# Altium schematic sheet A4-4G_MODULE.SchDoc
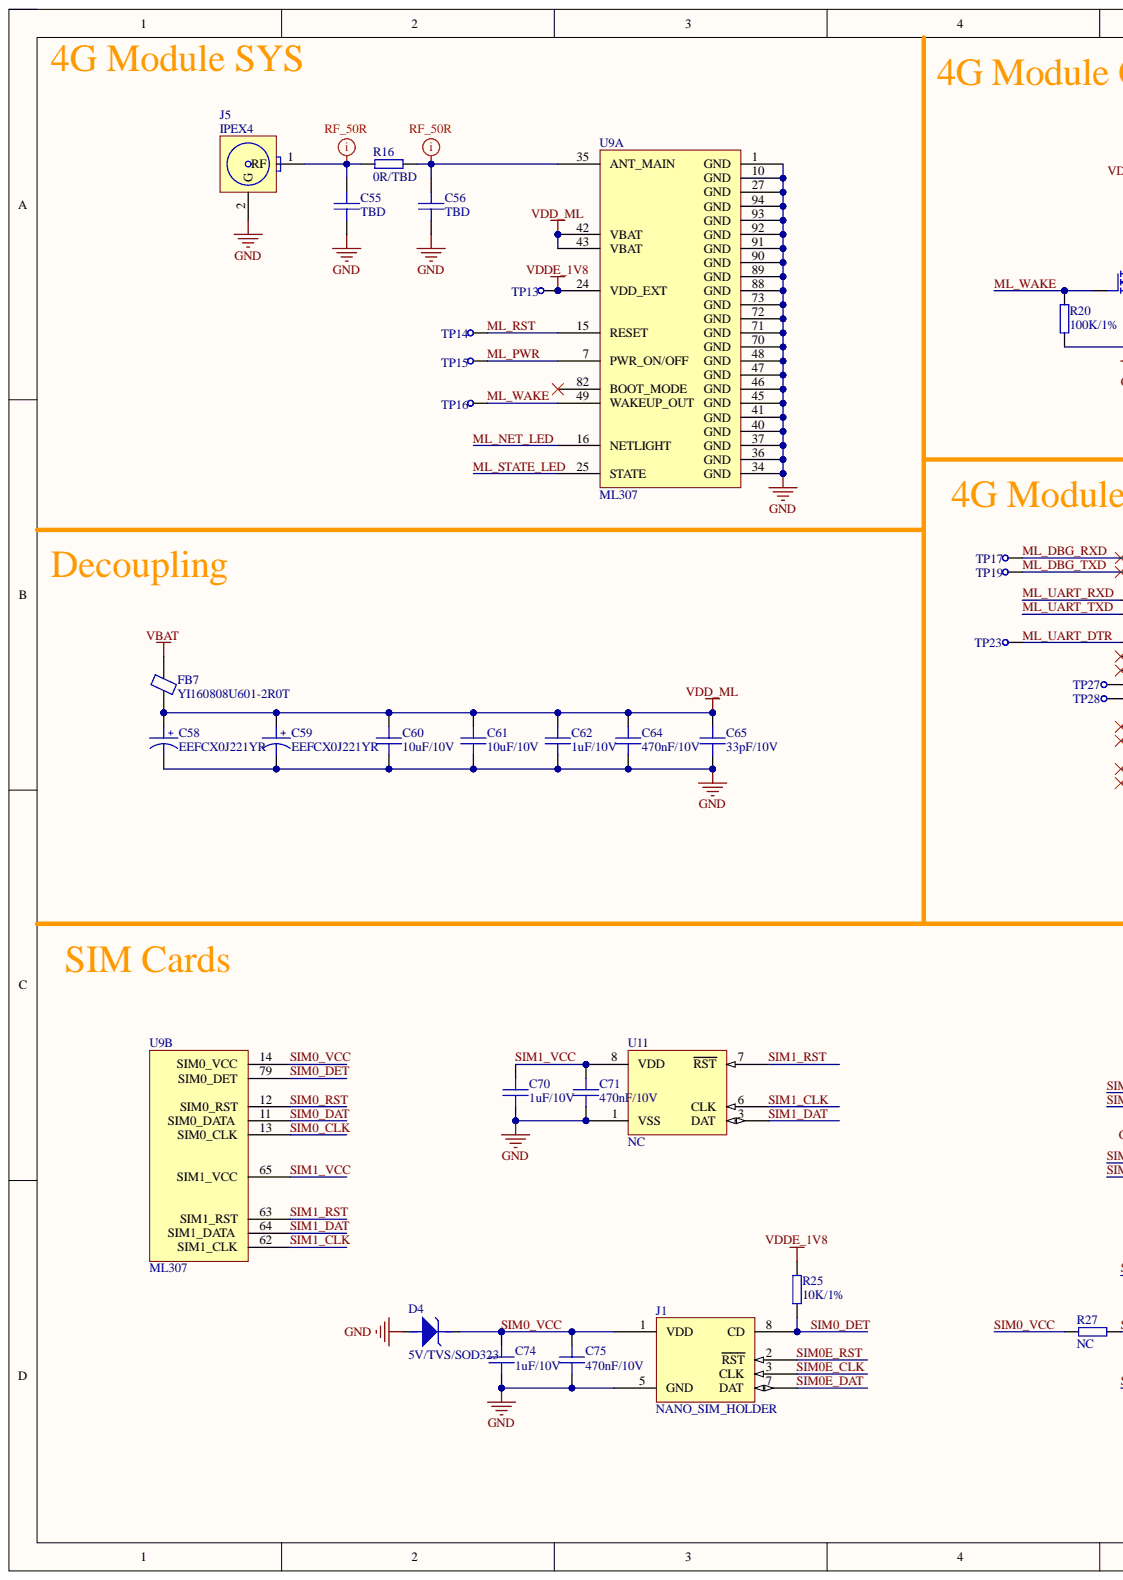
[diagram p0: A4-4G_MODULE.SchDoc, left]
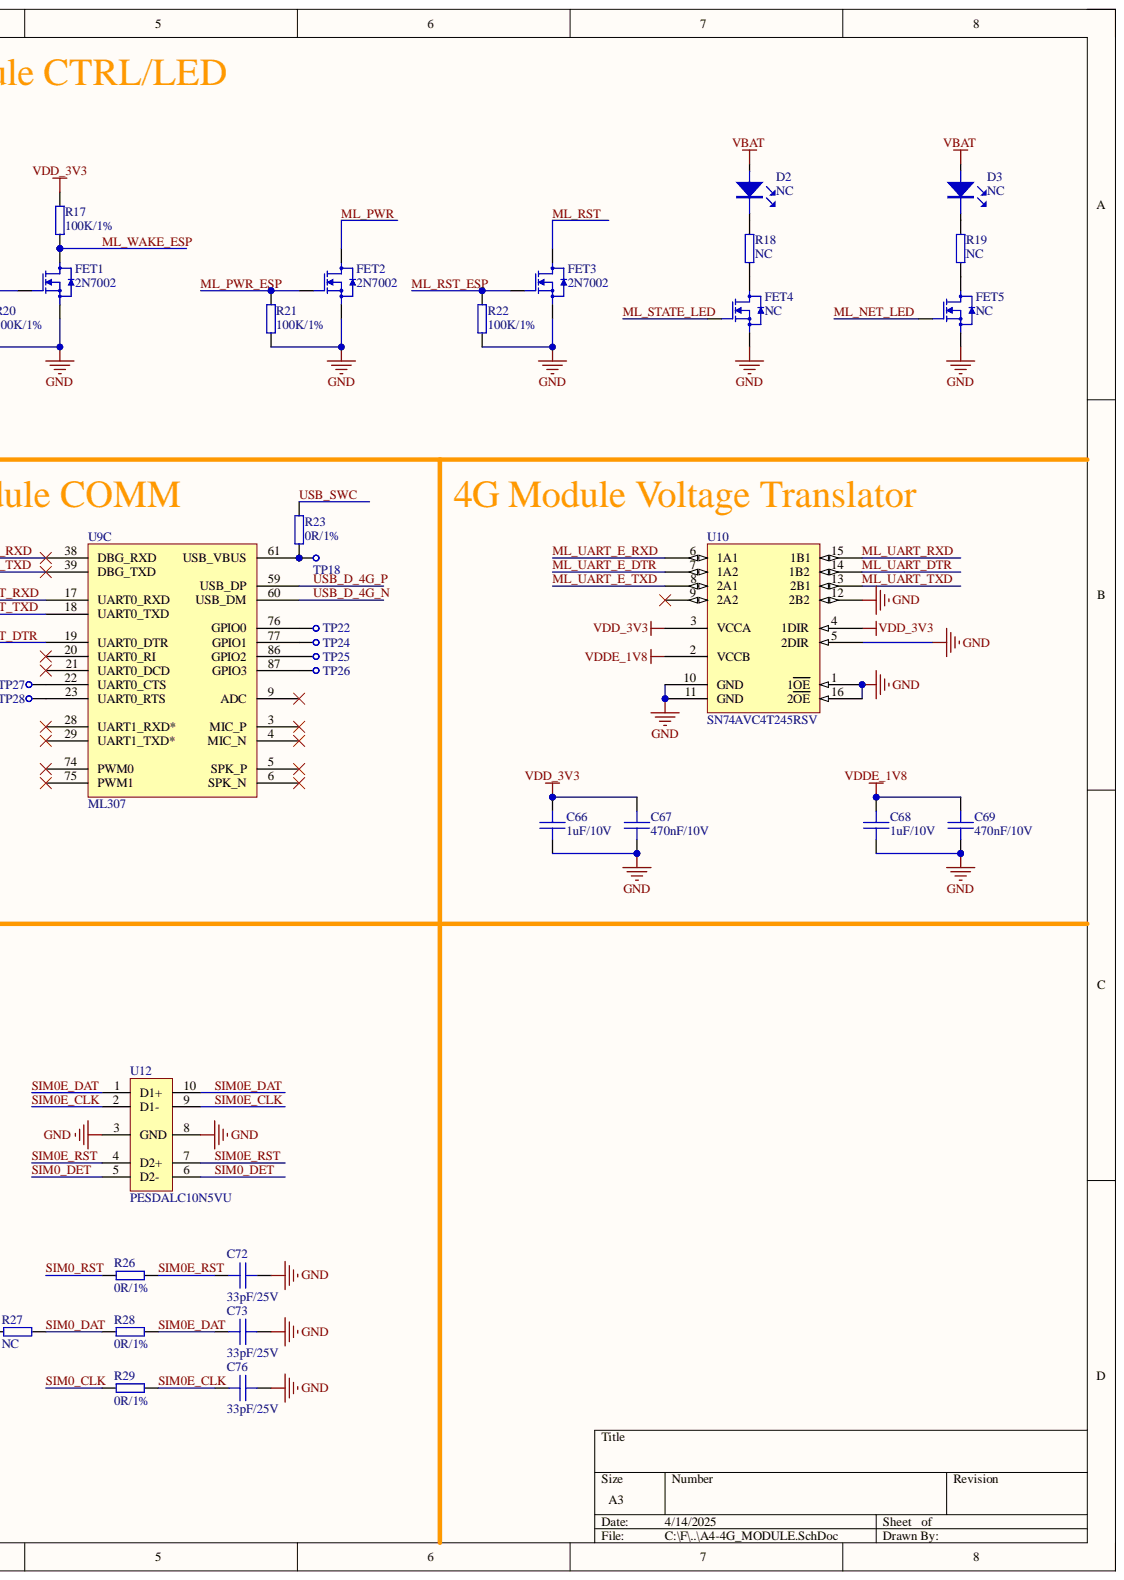
[diagram p1: A4-4G_MODULE.SchDoc, right]

## Parts
C55  TBD  [C0402-HD]
C56  TBD  [C0402-HD]
C58  EEFCX0J221YR  [SMT_C_Tantalum_V]
C59  EEFCX0J221YR  [SMT_C_Tantalum_V]
C60  10uF/10V  [C0402-L-HD]
C61  10uF/10V  [C0402-L-HD]
C62  1uF/10V  [C0201-HD]
C64  470nF/10V  [C0201-HD]
C65  33pF/10V  [C0201-HD]
C66  1uF/10V  [C0201-HD]
C67  470nF/10V  [C0201-HD]
C68  1uF/10V  [C0201-HD]
C69  470nF/10V  [C0201-HD]
C70  1uF/10V  [C0201-HD]
C71  470nF/10V  [C0201-HD]
C72  33pF/25V  [C0201-HD]
C73  33pF/25V  [C0201-HD]
C74  1uF/10V  [C0201-HD]
C75  470nF/10V  [C0201-HD]
C76  33pF/25V  [C0201-HD]
D2  NC  [SMT_LED_0603]
D3  NC  [SMT_LED_0603]
D4  5V/TVS/SOD323  [SOD-323FL-HD]
FB7  YI160808U601-2R0T  [L0603-HD]
FET1  2N7002  [SOT-723-HD]
FET2  2N7002  [SOT-723-HD]
FET3  2N7002  [SOT-723-HD]
FET4  NC  [SOT-723-HD]
FET5  NC  [SOT-723-HD]
J1  NANO_SIM_HOLDER  [NANOSIM_EJECT_H137]
J5  IPEX4  [IPEX4]
R16  0R/TBD  [C0402-HD]
R17  100K/1%  [R0402-HD]
R18  NC  [R0402-HD]
R19  NC  [R0402-HD]
R20  100K/1%  [R0402-HD]
R21  100K/1%  [R0402-HD]
R22  100K/1%  [R0402-HD]
R23  0R/1%  [R0402-HD]
R25  10K/1%  [R0402-HD]
R26  0R/1%  [R0402-HD]
R27  NC  [R0402-HD]
R28  0R/1%  [R0402-HD]
R29  0R/1%  [R0402-HD]
TP13  SMT_TEST_POINT  [SMT_TEST_POINT]  (value not drawn)
TP14  SMT_TEST_POINT  [SMT_TEST_POINT]  (value not drawn)
TP15  SMT_TEST_POINT  [SMT_TEST_POINT]  (value not drawn)
TP16  SMT_TEST_POINT  [SMT_TEST_POINT]  (value not drawn)
TP17  SMT_TEST_POINT  [SMT_TEST_POINT]  (value not drawn)
TP18  SMT_TEST_POINT  [SMT_TEST_POINT]  (value not drawn)
TP19  SMT_TEST_POINT  [SMT_TEST_POINT]  (value not drawn)
TP22  SMT_TEST_POINT  [SMT_TEST_POINT]  (value not drawn)
TP23  SMT_TEST_POINT  [SMT_TEST_POINT]  (value not drawn)
TP24  SMT_TEST_POINT  [SMT_TEST_POINT]  (value not drawn)
TP25  SMT_TEST_POINT  [SMT_TEST_POINT]  (value not drawn)
TP26  SMT_TEST_POINT  [SMT_TEST_POINT]  (value not drawn)
TP27  SMT_TEST_POINT  [SMT_TEST_POINT]  (value not drawn)
TP28  SMT_TEST_POINT  [SMT_TEST_POINT]  (value not drawn)
U9  ML307  [ML307_LGA94]  (parts A,B,C)
U10  SN74AVC4T245RSV  [RSV16-UQFN-16P]
U11  NC  [SON127P600X80_HS-9L]
U12  PESDALC10N5VU  [R-PSON-N10]

## Nets
GND: C55.1 C56.1 C58.2 C59.2 C60.1 C61.1 C62.2 C64.1 C65.1 C66.1 C67.2 C68.1 C69.2 C70.2 C71.1 C72.2 C73.2 C74.2 C75.1 C76.2 D4.1 FET1.2 FET2.2 FET3.2 FET4.2 FET5.2 J1.5(GND) J5.2 R20.2 R21.2 R22.2 U9.1(GND) U9.10(GND) U9.27(GND) U9.34(GND) U9.36(GND) U9.37(GND) U9.40(GND) U9.41(GND) U9.45(GND) U9.46(GND) U9.47(GND) U9.48(GND) U9.70(GND) U9.71(GND) U9.72(GND) U9.73(GND) U9.88(GND) U9.89(GND) U9.90(GND) U9.91(GND) U9.92(GND) U9.93(GND) U9.94(GND) U10.1(1OE) U10.5(2DIR) U10.10(GND) U10.11(GND) U10.12(2B2) U10.16(2OE) U11.1(VSS) U12.3 U12.8(GND)
VBAT: D2.1 D3.1 FB7.1
VDD_3V3: C66.2 C67.1 R17.1 U10.3(VCCA) U10.4(1DIR)
VDD_ML: C58.1 C59.1 C60.2 C61.2 C62.1 C64.2 C65.2 FB7.2 U9.42(VBAT) U9.43(VBAT)
VDDE_1V8: C68.2 C69.1 R25.1 TP13.1 U9.24(VDD_EXT) U10.2(VCCB)
ML_DBG_RXD: TP17.1 U9.38(DBG_RXD)
ML_DBG_TXD: TP19.1 U9.39(DBG_TXD)
ML_NET_LED: FET5.1 U9.16(NETLIGHT)
ML_PWR: FET2.3 TP15.1 U9.7(PWR_ON/OFF)
ML_PWR_ESP: FET2.1 R21.1
ML_RST: FET3.3 TP14.1 U9.15(RESET)
ML_RST_ESP: FET3.1 R22.1
ML_STATE_LED: FET4.1 U9.25(STATE)
ML_UART_DTR: TP23.1 U9.19(UART0_DTR) U10.14(1B2)
ML_UART_E_DTR: U10.7(1A2)
ML_UART_E_RXD: U10.6(1A1)
ML_UART_E_TXD: U10.8(2A1)
ML_UART_RXD: U9.17(UART0_RXD) U10.15(1B1)
ML_UART_TXD: U9.18(UART0_TXD) U10.13(2B1)
ML_WAKE: FET1.1 R20.1 TP16.1 U9.49(WAKEUP_OUT)
ML_WAKE_ESP: FET1.3 R17.2
SIM0_CLK: R29.2 U9.13(SIM0_CLK)
SIM0_DAT: R27.1 R28.2 U9.11(SIM0_DATA)
SIM0_DET: J1.8(CD) R25.2 U9.79(SIM0_DET) U12.5(D2-) U12.6
SIM0_RST: R26.2 U9.12(SIM0_RST)
SIM0_VCC: C74.1 C75.2 D4.2 J1.1(VDD) R27.2 U9.14(SIM0_VCC)
SIM0E_CLK: C76.1 J1.3(CLK) R29.1 U12.2(D1-) U12.9
SIM0E_DAT: C73.1 J1.7(DAT) R28.1 U12.1 U12.10(D1+)
SIM0E_RST: C72.1 J1.2(RST) R26.1 U12.4 U12.7(D2+)
SIM1_CLK: U9.62(SIM1_CLK) U11.6(CLK)
SIM1_DAT: U9.64(SIM1_DATA) U11.3(DAT)
SIM1_RST: U9.63(SIM1_RST) U11.7(RST)
SIM1_VCC: C70.1 C71.2 U9.65(SIM1_VCC) U11.8(VDD)
USB_D_4G_N: U9.60(USB_DM)
USB_D_4G_P: U9.59(USB_DP)
USB_SWC: R23.1
NetC55_2: C55.2 J5.1 R16.1
NetC56_2: C56.2 R16.2 U9.35(ANT_MAIN)
NetD2_2: D2.2 R18.1
NetD3_2: D3.2 R19.1
NetFET4_3: FET4.3 R18.2
NetFET5_3: FET5.3 R19.2
NetR23_2: R23.2 TP18.1 U9.61(USB_VBUS)
NetTP22_1: TP22.1 U9.76(GPIO0)
NetTP24_1: TP24.1 U9.77(GPIO1)
NetTP25_1: TP25.1 U9.86(GPIO2)
NetTP26_1: TP26.1 U9.87(GPIO3)
NetTP27_1: TP27.1 U9.22(UART0_CTS)
NetTP28_1: TP28.1 U9.23(UART0_RTS)

Not connected: U9 3(MIC_P) 4(MIC_N) 5(SPK_P) 6(SPK_N) 9(ADC) 20(UART0_RI) 21(UART0_DCD) 28(UART1_RXD*) 29(UART1_TXD*) 74(PWM0) 75(PWM1) 82(BOOT_MODE); U10 9(2A2)

## Library data of the parts
C55 C56 C60-C62 C64-C76 = SMT_C_0402: Surface mount capacitor 0402; Component Kind: Standard; Library Name: Vowstar_Basic_Device.SchLib
C58 C59 = SMT_C_Tantalum_V: Capacitor, SM Tantalum; Body 7.3 x 4.3 mm (LxW typ); Component Kind: Standard; Library Name: Vowstar_Basic_Device.SchLib
D2 D3 = SMT_LED_0603: Surface mount LED 0603; Component Kind: Standard; Library Name: Vowstar_Basic_Device.SchLib
D4 = SMT_DIODE_TVS_U_SOD_523: Surface mount unidirectional TVS diode SOD-523(0603) Package; Component Kind: Standard; Library Name: Vowstar_Basic_Device.SchLib
FB7 = SMT_FB_0603: Surface mount ferrite beads 0603; Component Kind: Standard; Library Name: Vowstar_Basic_Device.SchLib
FET1-FET5 = SI2302-N-Ch-MOSFET: SI2302-N-Ch,3A, 20V.RDS(ON) = 0.082Ω @ VGS = 2.5 V; Component Kind: Standard; Library Name: Vowstar_Basic_Device.SchLib
J5 = IPEX: IPEX RF connector; Component Kind: Standard; Library Name: Vowstar_Connector.SchLib
R16-R23 R25-R29 = SMT_R_0402: Surface mount resistor 0402; Component Kind: Standard; Library Name: Vowstar_Basic_Device.SchLib
TP13-TP19 TP22-TP28 = SMT_TEST_POINT: SMT Test Point; Component Kind: Standard; Library Name: Vowstar_Basic_Device.SchLib
U11 = eSIM: Component Kind: Standard; Library Name: GENERAL-IC.SchLib
U12 = TPD4E05U06: Component Kind: Standard; Library Name: GENERAL-IC.SchLib
J1 = NANO_SIM_HOLDER: Component Kind: Standard; Library Name: GENERAL-IC.SchLib
U9 = ML307: Component Kind: Standard; Library Name: GENERAL-IC.SchLib
U10 = SN74AVC4T245RSV: Component Kind: Standard; Library Name: GENERAL-IC.SchLib
